# Altium schematic sheet rect.SchDoc(rect)
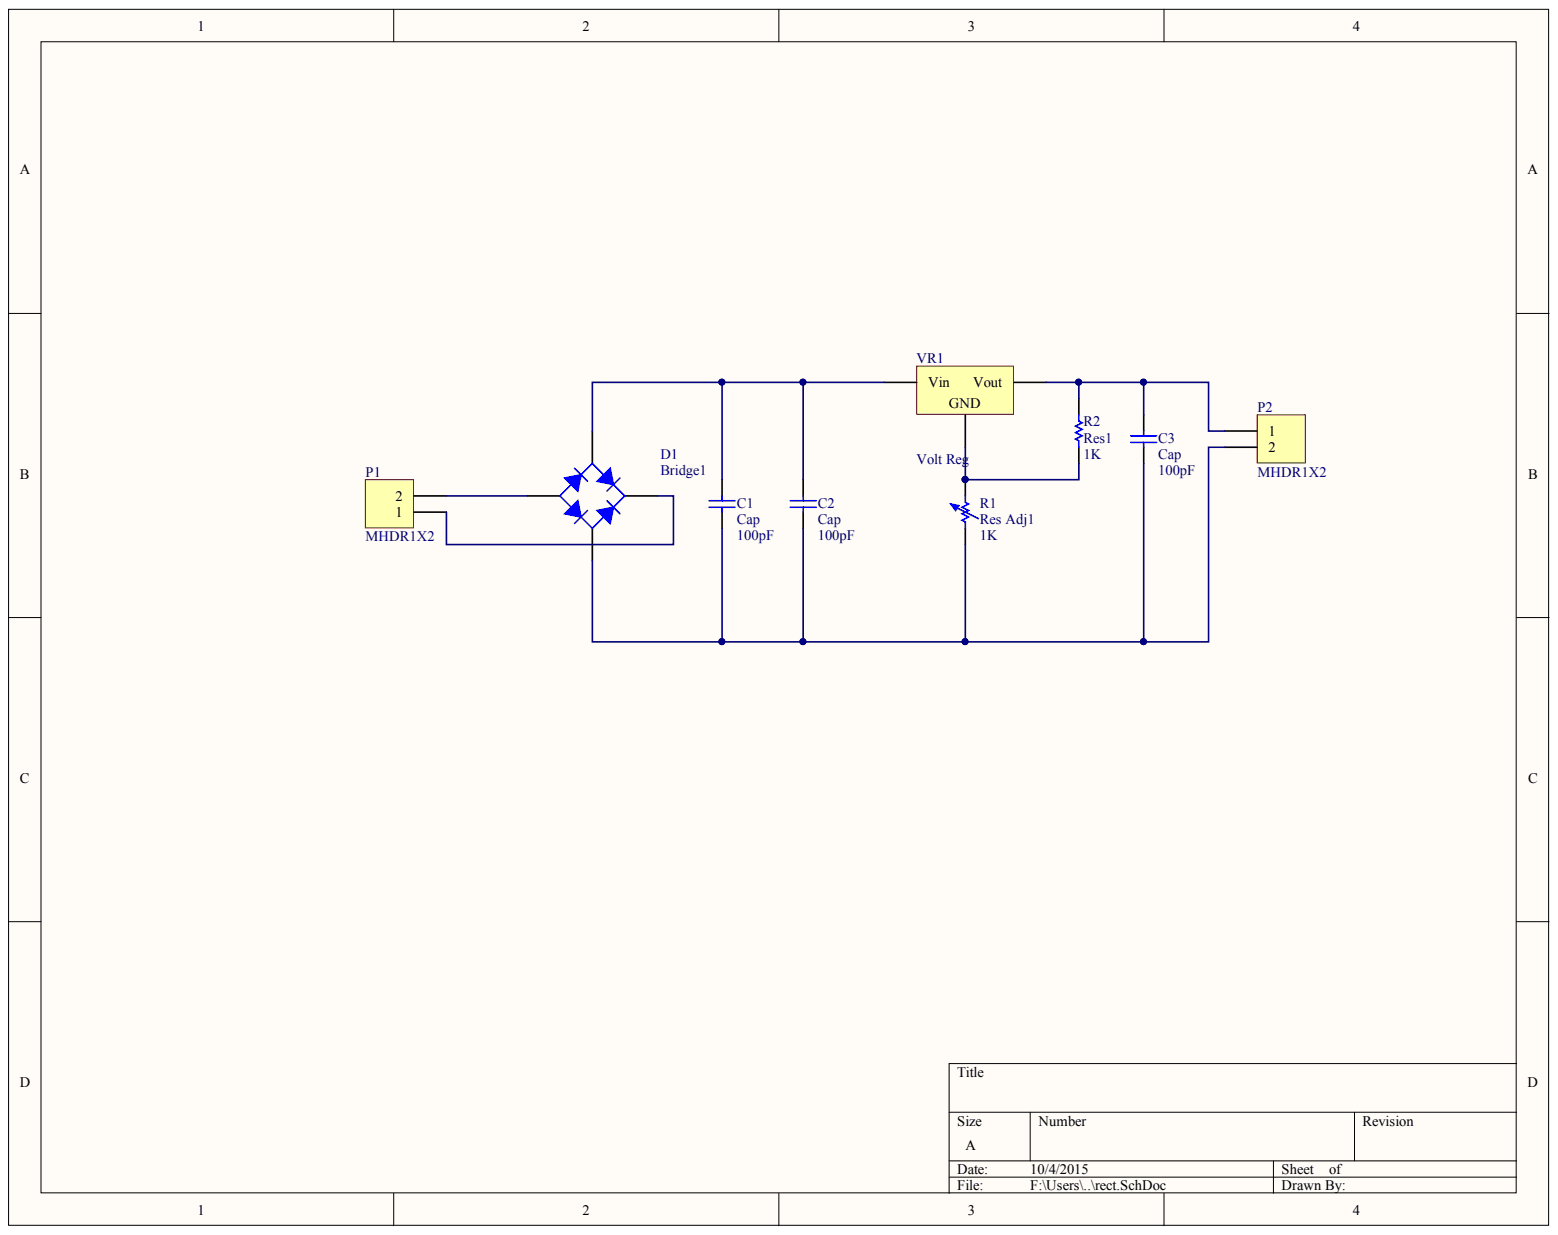
[diagram p0: rect.SchDoc]

## Parts
C1  Cap  value 100pF  [RAD-0.3]
C2  Cap  value 100pF  [RAD-0.3]
C3  Cap  value 100pF  [RAD-0.3]
D1  Bridge1  [D-38]
P1  MHDR1X2  [MHDR1X2]
P2  MHDR1X2  [MHDR1X2]
R1  Res Adj1  value 1K  [AXIAL-0.7]
R2  Res1  value 1K  [AXIAL-0.3]
VR1  Volt Reg  [D2PAK_N]

## Nets
NetC1_1: C1.1 C2.1 C3.1 D1.2 P2.2 R1.1
NetC1_2: C1.2 C2.2 D1.4 VR1.1
NetC3_2: C3.2 P2.1 R2.2 VR1.3
NetD1_1: D1.1 P1.1
NetD1_3: D1.3 P1.2
NetR1_2: R1.2 R2.1 VR1.2

## Library data of the parts
C1-C3 = Cap: Capacitor; Component Kind: Standard; LatestRevisionDate: 17-Jul-2002; LatestRevisionNote: Re-released for DXP Platform.; Library Name: Miscellaneous Devices.IntLib; PackageDescription: Radial Cap, Thru-Hole; 2 Leads; 0.3 in Pin Spacing; PackageReference: RAD-0.3; Published: 8-Jun-2000; Signal Integrity: Cap; Simulation: CAP; Publisher: Altium Limited
D1 = Bridge1: Full Wave Diode Bridge; Component Kind: Standard; DatasheetVersion: E2732B; LatestRevisionDate: 29-May-2009; LatestRevisionNote: Sch pins A & K swapped.; Library Name: Miscellaneous Devices.IntLib; PackageDescription: Bridge Rectifier; 4 Terminals; Body 9.4 x 9.4 mm (typ); Pitch 5.08 mm; PackageReference: D-38; Published: 8-Jun-2000; Simulation: BRIDGE; Publisher: Altium Limited
P1 P2 = MHDR1X2: Header, 2-Pin; Component Kind: Standard; LatestRevisionDate: 17-Jul-2002; LatestRevisionNote: Re-released for DXP Platform.; Library Name: Miscellaneous Connectors.IntLib; Signal Integrity: Connector; Publisher: Altium Limited
R1 = Res Adj1: Variable Resistor; Set Position: 0.5; Component Kind: Standard; LatestRevisionDate: 17-Jul-2002; LatestRevisionNote: Re-released for DXP Platform.; Library Name: Miscellaneous Devices.IntLib; PackageDescription: Axial Device, Thru-Hole; 2 Leads; 0.7 in Pin Spacing; PackageReference: AXIAL-0.7; Published: 8-Jun-2000; Signal Integrity: Res Adj; Simulation: VRES; Publisher: Altium Limited
R2 = Res1: Resistor; Component Kind: Standard; LatestRevisionDate: 17-Jul-2002; LatestRevisionNote: Re-released for DXP Platform.; Library Name: Miscellaneous Devices.IntLib; PackageDescription: Axial Device, Thru-Hole; 2 Leads; 0.3 in Pin Spacing; PackageReference: AXIAL-0.3; Published: 8-Jun-2000; Signal Integrity: Res; Simulation: RESISTOR; Publisher: Altium Limited
VR1 = Volt Reg: Voltage Regulator; Component Kind: Standard; LatestRevisionDate: 29-May-2009; LatestRevisionNote: IPC-7351 Footprint Added.; Library Name: Miscellaneous Devices.IntLib; PackageDescription: 3-Pin Transistor Outline Package 2.54 mm Pitch, 15.1 mm Lead Span; PackageReference: D2PAK; Published: 24-Mar-1999; Publisher: Altium Limited
